# Altium schematic sheet Touch_CPU.SchDoc(Touch_CPU)
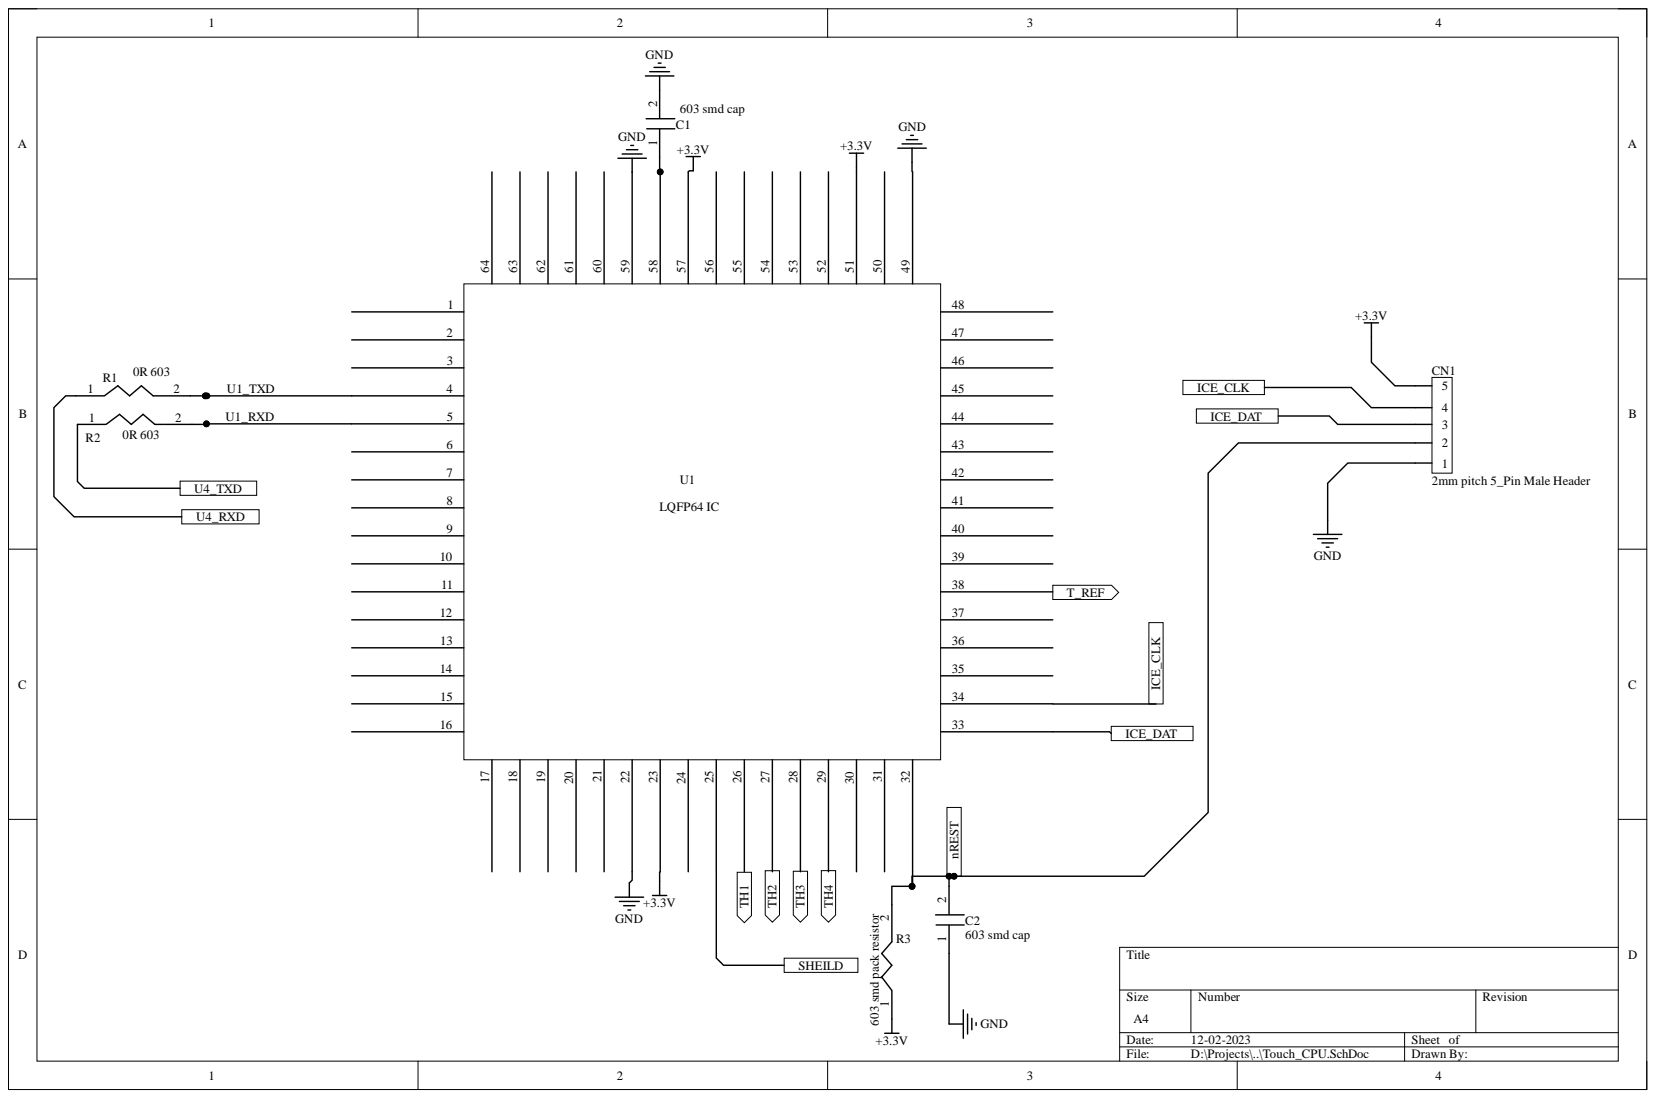
[diagram p0: Touch_CPU.SchDoc]

## Parts
C1  603 smd cap  [603]
C2  603 smd cap  [603]
CN1  2mm pitch 5_Pin Male Header  [2mm pitch 5Pin Male header]
R1  0R 603  [603]
R2  0R 603  [603]
R3  603 smd pack resistor  [603]
U1  LQFP64 IC  [LQFP-64]

## Ports
ICE_CLK, ICE_DAT, nREST, SHEILD, T_REF, TH1, TH2, TH3, TH4, U4_RXD, U4_TXD

## Nets
+3.3V: CN1.5 R3.1 U1.23 U1.51 U1.57
GND: C1.2 C2.1 CN1.1 U1.22 U1.49
ICE_CLK: CN1.4 U1.34 ; port ICE_CLK
ICE_DAT: CN1.3 U1.33 ; port ICE_DAT
nREST: C2.2 CN1.2 R3.2 U1.32 ; port nREST
U1_RXD: R2.2 U1.5
U1_TXD: R1.2 U1.4
U4_RXD: R1.1 ; port U4_RXD
U4_TXD: R2.1 ; port U4_TXD
NetC1_1: C1.1 U1.58
N00001: ; port SHEILD
N00002: ; port TH4
N00003: ; port T_REF
N00004: ; port TH1
N00005: ; port TH2
N00006: ; port TH3

Not connected: U1 1 2 3 6 7 8 9 10 11 12 13 14 15 16 17 18 19 20 21 24 25 26 27 28 29 30 31 35 36 37 38 39 40 41 42 43 44 45 46 47 48 50 52 53 54 55 56 59 60 61 62 63 64

## Library data of the parts
CN1 = Male Header: Component Kind: Standard; Library Name: Custom1.IntLib
R1-R3 = 603 Resistor: Component Kind: Standard; Library Name: Custom1.IntLib
U1 = LQFP64: Component Kind: Standard; Library Name: Custom1.IntLib
C1 C2 = 603 SMD CAP: Component Kind: Standard; Library Name: Custom1.IntLib
